# Altium schematic sheet 7寸触摸显示屏-V1.0.SchDoc
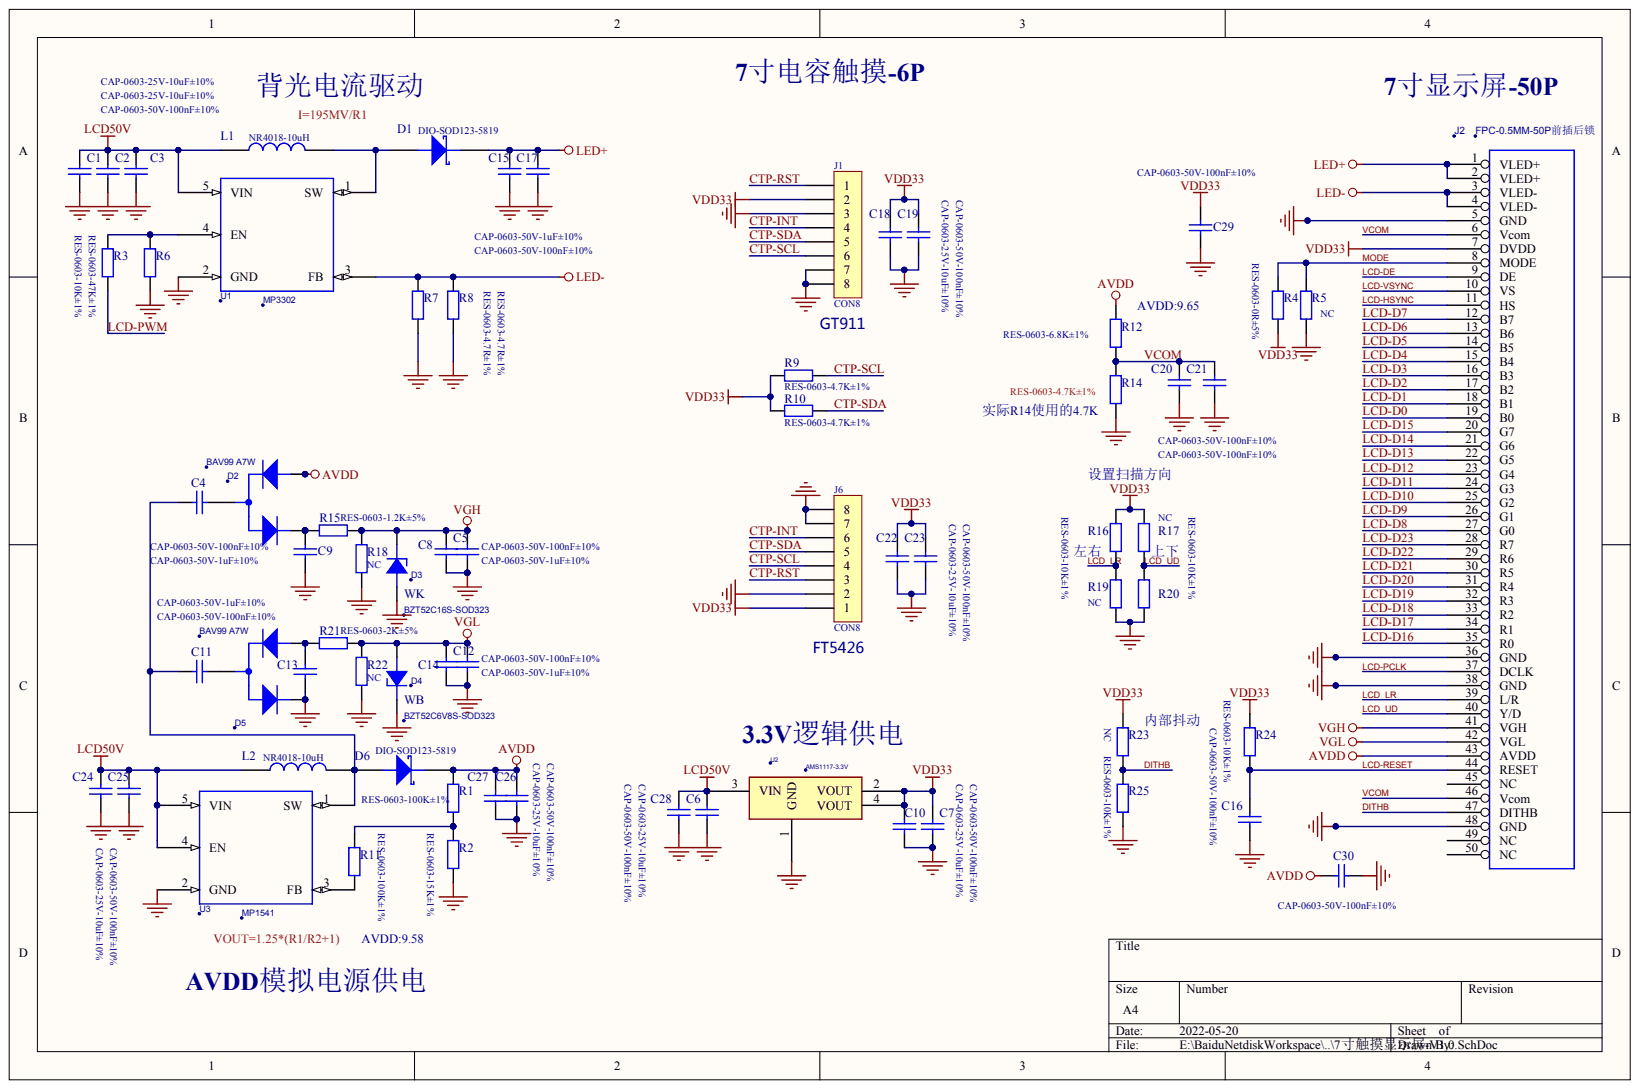
[diagram p0: 7寸触摸显示屏-V1.0.SchDoc]

## Parts
C1  CAP-0603-25V-10uF±10%  [0603T]
C2  CAP-0603-25V-10uF±10%  [0603T]
C3  CAP-0603-50V-100nF±10%  [0603T]
C4  CAP-0603-50V-100nF±10%  [0603T]
C5  CAP-0603-50V-100nF±10%  [0603T]
C6  CAP-0603-25V-10uF±10%  [0603T]
C7  CAP-0603-50V-100nF±10%  [0603T]
C8  CAP-0603-50V-1uF±10%  [0603T]
C9  CAP-0603-50V-1uF±10%  [0603T]
C10  CAP-0603-25V-10uF±10%  [0603T]
C11  CAP-0603-50V-100nF±10%  [0603T]
C12  CAP-0603-50V-100nF±10%  [0603T]
C13  CAP-0603-50V-1uF±10%  [0603T]
C14  CAP-0603-50V-1uF±10%  [0603T]
C15  CAP-0603-50V-1uF±10%  [0603T]
C16  CAP-0603-50V-100nF±10%  [0603T]
C17  CAP-0603-50V-100nF±10%  [0603T]
C18  CAP-0603-25V-10uF±10%  [0603T]
C19  CAP-0603-50V-100nF±10%  [0603T]
C20  CAP-0603-50V-100nF±10%  [0603T]
C21  CAP-0603-50V-100nF±10%  [0603T]
C22  CAP-0603-25V-10uF±10%  [0603T]
C23  CAP-0603-50V-100nF±10%  [0603T]
C24  CAP-0603-25V-10uF±10%  [0603T]
C25  CAP-0603-50V-100nF±10%  [0603T]
C26  CAP-0603-50V-100nF±10%  [0603T]
C27  CAP-0603-25V-10uF±10%  [0603T]
C28  CAP-0603-50V-100nF±10%  [0603T]
C29  CAP-0603-50V-100nF±10%  [0603T]
C30  CAP-0603-50V-100nF±10%  [0603T]
D1  DIO-SOD123-5819  [SOD-123]
D2  BAV99 A7W  [SOT-23]
D3  BZT52C16S-SOD323  [D0805]
D4  BZT52C6V8S-SOD323  [D0805]
D5  BAV99 A7W  [SOT-23]
D6  DIO-SOD123-5819  [SOD-123]
J1  CON8  [FPC0.5-6]
J2  FPC-0.5MM-50P前插后锁  [FPC0.5-50X]
J6  CON8  [FPC0.5-6]
L1  NR4018-10uH  [1210]
L2  NR4018-10uH  [1210]
R1  RES-0603-100K±1%  [0603T]
R2  RES-0603-15K±1%  [0603T]
R3  RES-0603-10K±1%  [0603T]
R4  RES-0603-0R±5%  [0603T]
R5  NC  [0603T]
R6  RES-0603-47K±1%  [0603T]
R7  RES-0603-4.7R±1%  [0603T]
R8  RES-0603-4.7R±1%  [0603T]
R9  RES-0603-4.7K±1%  [0603T]
R10  RES-0603-4.7K±1%  [0603T]
R11  RES-0603-100K±1%  [0603T]
R12  RES-0603-6.8K±1%  [0603T]
R14  RES-0603-4.7K±1%  [0603T]
R15  RES-0603-1.2K±5%  [0603T]
R16  RES-0603-10K±1%  [0603T]
R17  NC  [0603T]
R18  NC  [0603T]
R19  NC  [0603T]
R20  RES-0603-10K±1%  [0603T]
R21  RES-0603-2K±5%  [0603T]
R22  NC  [0603T]
R23  NC  [0603T]
R24  RES-0603-10K±1%  [0603T]
R25  RES-0603-10K±1%  [0603T]
U1  MP3302  [SOT-23-5]
U2  AMS1117-3.3V  [SOT-223]
U3  MP1541  [SOT-23-5]

## Nets
AVDD: C26.2 C27.1 C30.2 D2.1 D6.2 J2.43(AVDD) R1.1 R12.1
GND: C1.2 C2.2 C3.1 C5.1 C6.2 C7.1 C8.2 C9.1 C10.2 C12.1 C13.1 C14.2 C15.2 C16.1 C17.1 C18.2 C19.1 C20.1 C21.1 C22.2 C23.1 C24.2 C25.1 C26.1 C27.2 C28.1 C29.2 C30.1 D3.1 D4.2 D5.2 J1.3 J1.7 J1.8 J2.5(GND) J2.36(GND) J2.38(GND) J2.48(GND) J6.2 J6.7 J6.8 R2.2 R5.2 R6.2 R7.2 R8.2 R14.2 R18.2 R19.2 R20.2 R22.2 R25.2 U1.2(GND) U2.1(GND) U3.2(GND)
LCD50V: C1.1 C2.1 C3.2 C6.1 C24.1 C25.2 C28.2 L1.1 L2.1 U1.5(VIN) U2.3(VIN) U3.4(EN) U3.5(VIN)
LED+: C15.1 C17.2 D1.2 J2.1(VLED+) J2.2(VLED+)
LED-: J2.3(VLED-) J2.4(VLED-) R7.1 R8.1 U1.3(FB)
VDD33: C7.2 C10.1 C18.1 C19.2 C22.1 C23.2 C29.1 J1.2 J2.7(DVDD) J6.1 R4.2 R9.1 R10.1 R16.1 R17.1 R23.1 R24.1 U2.2(VOUT) U2.4(VOUT)
VGH: C5.2 C8.1 D3.2 J2.41(VGH) R15.2 R18.1
VGL: C12.2 C14.1 D4.1 J2.42(VGL) R21.2 R22.1
CTP-INT: J1.4 J6.6
CTP-RST: J1.1 J6.3
CTP-SCL: J1.6 J6.4 R9.2
CTP-SDA: J1.5 J6.5 R10.2
DITHB: J2.47(DITHB) R23.2 R25.1
LCD-D0: J2.19(B0)
LCD-D1: J2.18(B1)
LCD-D2: J2.17(B2)
LCD-D3: J2.16(B3)
LCD-D4: J2.15(B4)
LCD-D5: J2.14(B5)
LCD-D6: J2.13(B6)
LCD-D7: J2.12(B7)
LCD-D8: J2.27(G0)
LCD-D9: J2.26(G1)
LCD-D10: J2.25(G2)
LCD-D11: J2.24(G3)
LCD-D12: J2.23(G4)
LCD-D13: J2.22(G5)
LCD-D14: J2.21(G6)
LCD-D15: J2.20(G7)
LCD-D16: J2.35(R0)
LCD-D17: J2.34(R1)
LCD-D18: J2.33(R2)
LCD-D19: J2.32(R3)
LCD-D20: J2.31(R4)
LCD-D21: J2.30(R5)
LCD-D22: J2.29(R6)
LCD-D23: J2.28(R7)
LCD-DE: J2.9(DE)
LCD-HSYNC: J2.11(HS)
LCD-PCLK: J2.37(DCLK)
LCD-PWM: R3.1
LCD-RESET: C16.2 J2.44(RESET) R24.2
LCD-VSYNC: J2.10(VS)
LCD_LR: J2.39(L/R) R16.2 R19.1
LCD_UD: J2.40(Y/D) R17.2 R20.1
MODE: J2.8(MODE) R4.1 R5.1
VCOM: C20.2 C21.2 J2.6(Vcom) J2.46(Vcom) R12.2 R14.1
NetC4_1: C4.1 D2.3
NetC4_2: C4.2 C11.2 D6.1 L2.2 U3.1(SW)
NetC9_2: C9.2 D2.2 R15.1
NetC11_1: C11.1 D5.3
NetC13_2: C13.2 D5.1 R21.1
NetD1_1: D1.1 L1.2 U1.1(SW)
NetR1_2: R1.2 R2.1 R11.2
NetR3_2: R3.2 R6.1 U1.4(EN)
NetR11_1: R11.1 U3.3(FB)

Not connected: J2 45(NC) 49(NC) 50(NC)

## Library data of the parts
C1-C30 = Cap: Capacitor; Value: 0.01uF; Component Kind: Standard; LatestRevisionDate: 17-Jul-2002; LatestRevisionNote: Re-released for DXP Platform.; Library Name: Miscellaneous Devices.IntLib; PackageDescription: Radial Cap, Thru-Hole; 2 Leads; 0.3 in Pin Spacing; PackageReference: RAD-0.3; Published: 8-Jun-2000; Signal Integrity: Cap; Simulation: CAP; Publisher: Altium Limited
D1 D6 = DIODE SCHOTTKY: Schottky Diode; Component Kind: Standard
D2 D5 = BAT54S/SOT: Component Kind: Standard
D3 D4 = DIODE BREAKDOWN_1: Component Kind: Standard
J2 = HEADER 20X2_2: Populate: YES; Component Kind: Standard
L1 L2 = INDUCTOR: Component Kind: Standard
R1-R12 R14-R25 = Res2: Resistor; Value: 100K; Component Kind: Standard; LatestRevisionDate: 17-Jul-2002; LatestRevisionNote: Re-released for DXP Platform.; Library Name: Miscellaneous Devices.IntLib; PackageDescription: Axial Device, Thru-Hole; 2 Leads; 0.4 in Pin Spacing; PackageReference: AXIAL-0.4; Published: 8-Jun-2000; Signal Integrity: Res; Simulation: RESISTOR; Publisher: Altium Limited
U1 U3 = X9C102/SO_2: Component Kind: Standard
U2 = LM7805/TO_6: Component Kind: Standard
J1 J6 = CON8: Component Kind: Standard; Library Name: 注册机控制板A64.SCHLIB
